# Altium schematic sheet SDRAM.SchDoc
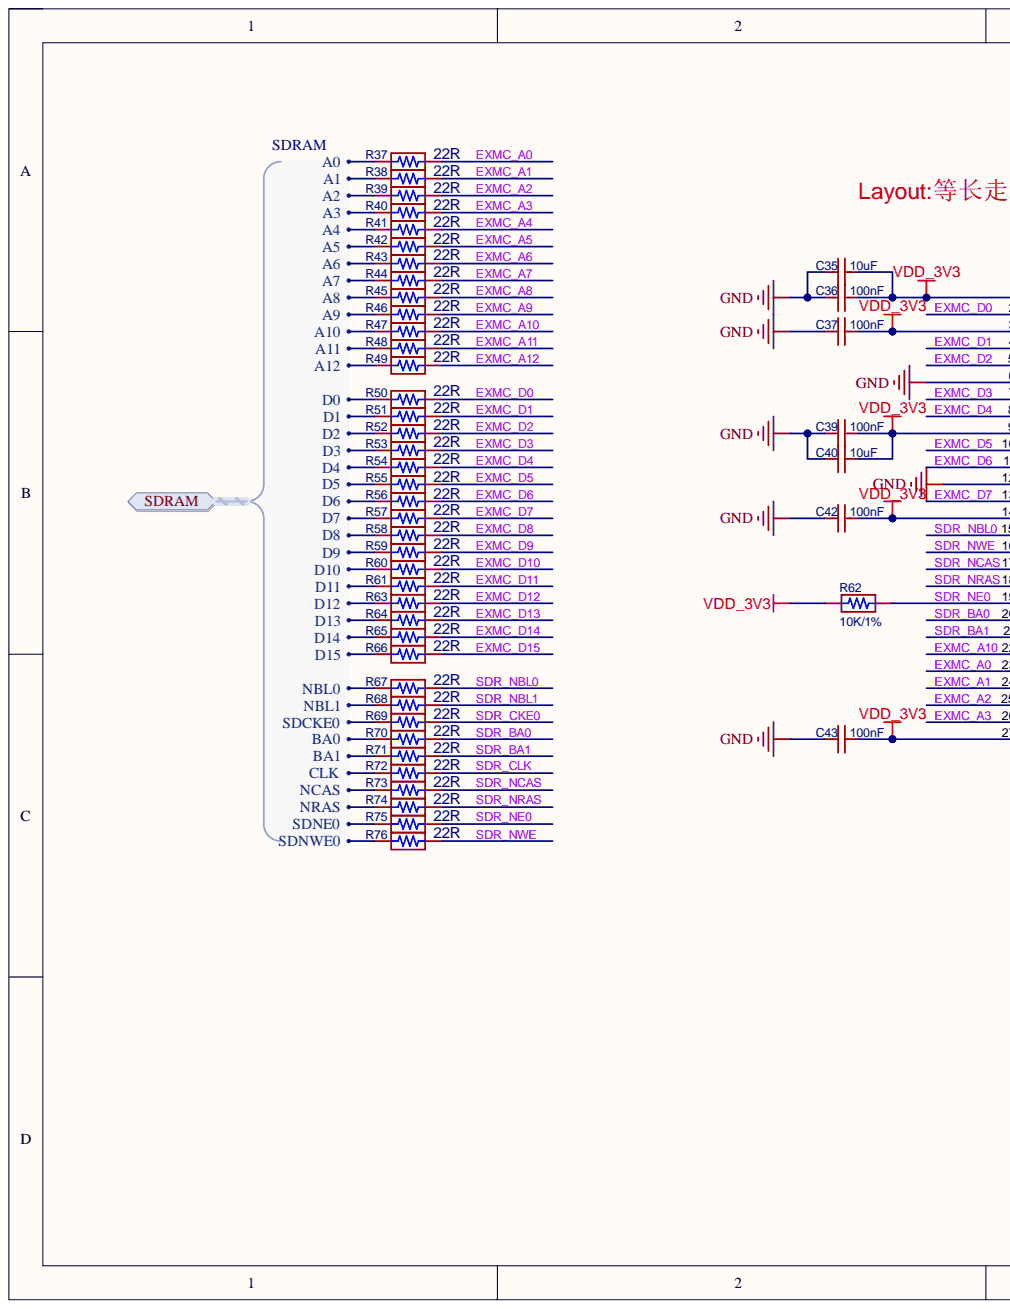
[diagram p0: SDRAM.SchDoc, left]
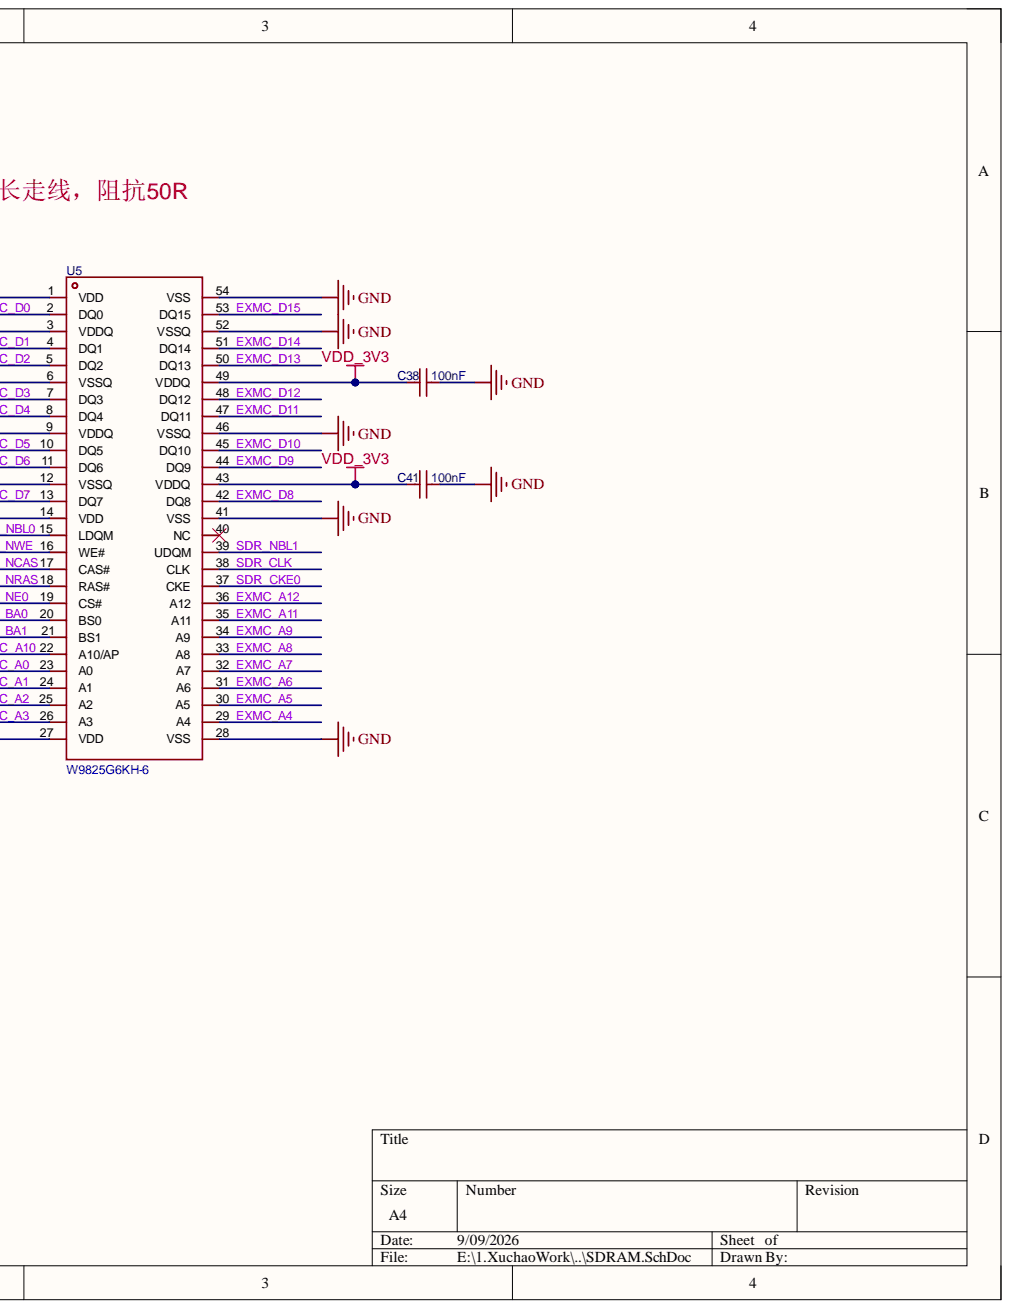
[diagram p1: SDRAM.SchDoc, right]

## Parts
C35  10uF  [C0402]
C36  100nF  [C0402]
C37  100nF  [C0402]
C38  100nF  [C0402]
C39  100nF  [C0402]
C40  10uF  [C0402]
C41  100nF  [C0402]
C42  100nF  [C0402]
C43  100nF  [C0402]
R37  22R  [R0402]
R38  22R  [R0402]
R39  22R  [R0402]
R40  22R  [R0402]
R41  22R  [R0402]
R42  22R  [R0402]
R43  22R  [R0402]
R44  22R  [R0402]
R45  22R  [R0402]
R46  22R  [R0402]
R47  22R  [R0402]
R48  22R  [R0402]
R49  22R  [R0402]
R50  22R  [R0402]
R51  22R  [R0402]
R52  22R  [R0402]
R53  22R  [R0402]
R54  22R  [R0402]
R55  22R  [R0402]
R56  22R  [R0402]
R57  22R  [R0402]
R58  22R  [R0402]
R59  22R  [R0402]
R60  22R  [R0402]
R61  22R  [R0402]
R62  10K/1%  [R0402]
R63  22R  [R0402]
R64  22R  [R0402]
R65  22R  [R0402]
R66  22R  [R0402]
R67  22R  [R0402]
R68  22R  [R0402]
R69  22R  [R0402]
R70  22R  [R0402]
R71  22R  [R0402]
R72  22R  [R0402]
R73  22R  [R0402]
R74  22R  [R0402]
R75  22R  [R0402]
R76  22R  [R0402]
U5  W9825G6KH-6  [TSOP-54_L22.2-W10.2-P0.80-LS11.8-BL]

## Ports
SDRAM, SDRAM.A0, SDRAM.A1, SDRAM.A2, SDRAM.A3, SDRAM.A4, SDRAM.A5, SDRAM.A6, SDRAM.A7, SDRAM.A8, SDRAM.A9, SDRAM.A10, SDRAM.A11, SDRAM.A12, SDRAM.BA0, SDRAM.BA1, SDRAM.CLK, SDRAM.D0, SDRAM.D1, SDRAM.D2, SDRAM.D3, SDRAM.D4, SDRAM.D5, SDRAM.D6, SDRAM.D7, SDRAM.D8, SDRAM.D9, SDRAM.D10, SDRAM.D11, SDRAM.D12, SDRAM.D13, SDRAM.D14, SDRAM.D15, SDRAM.NBL0, SDRAM.NBL1, SDRAM.NCAS, SDRAM.NRAS, SDRAM.SDCKE0, SDRAM.SDNE0, SDRAM.SDNWE0

## Nets
GND: C35.2 C36.1 C37.1 C38.2 C39.1 C40.2 C41.2 C42.1 C43.1 U5.6(VSSQ) U5.12(VSSQ) U5.28(VSS) U5.41(VSS) U5.46(VSSQ) U5.52(VSSQ) U5.54(VSS)
VDD_3V3: C35.1 C36.2 C37.2 C38.1 C39.2 C40.1 C41.1 C42.2 C43.2 R62.1 U5.1(VDD) U5.3(VDDQ) U5.9(VDDQ) U5.14(VDD) U5.27(VDD) U5.43(VDDQ) U5.49(VDDQ)
EXMC_A0: R37.2 U5.23(A0)
EXMC_A1: R38.2 U5.24(A1)
EXMC_A2: R39.2 U5.25(A2)
EXMC_A3: R40.2 U5.26(A3)
EXMC_A4: R41.2 U5.29(A4)
EXMC_A5: R42.2 U5.30(A5)
EXMC_A6: R43.2 U5.31(A6)
EXMC_A7: R44.2 U5.32(A7)
EXMC_A8: R45.2 U5.33(A8)
EXMC_A9: R46.2 U5.34(A9)
EXMC_A10: R47.2 U5.22(A10/AP)
EXMC_A11: R48.2 U5.35(A11)
EXMC_A12: R49.2 U5.36(A12)
EXMC_D0: R50.2 U5.2(DQ0)
EXMC_D1: R51.2 U5.4(DQ1)
EXMC_D2: R52.2 U5.5(DQ2)
EXMC_D3: R53.2 U5.7(DQ3)
EXMC_D4: R54.2 U5.8(DQ4)
EXMC_D5: R55.2 U5.10(DQ5)
EXMC_D6: R56.2 U5.11(DQ6)
EXMC_D7: R57.2 U5.13(DQ7)
EXMC_D8: R58.2 U5.42(DQ8)
EXMC_D9: R59.2 U5.44(DQ9)
EXMC_D10: R60.2 U5.45(DQ10)
EXMC_D11: R61.2 U5.47(DQ11)
EXMC_D12: R63.2 U5.48(DQ12)
EXMC_D13: R64.2 U5.50(DQ13)
EXMC_D14: R65.2 U5.51(DQ14)
EXMC_D15: R66.2 U5.53(DQ15)
SDR_BA0: R70.2 U5.20(BS0)
SDR_BA1: R71.2 U5.21(BS1)
SDR_CKE0: R69.2 U5.37(CKE)
SDR_CLK: R72.2 U5.38(CLK)
SDR_NBL0: R67.2 U5.15(LDQM)
SDR_NBL1: R68.2 U5.39(UDQM)
SDR_NCAS: R73.2 U5.17(CAS#)
SDR_NE0: R62.2 R75.2 U5.19(CS#)
SDR_NRAS: R74.2 U5.18(RAS#)
SDR_NWE: R76.2 U5.16(WE#)
NetR37_1: R37.1 ; port SDRAM
NetR38_1: R38.1 ; port SDRAM
NetR39_1: R39.1 ; port SDRAM
NetR40_1: R40.1 ; port SDRAM
NetR41_1: R41.1 ; port SDRAM
NetR42_1: R42.1 ; port SDRAM
NetR43_1: R43.1 ; port SDRAM
NetR44_1: R44.1 ; port SDRAM
NetR45_1: R45.1 ; port SDRAM
NetR46_1: R46.1 ; port SDRAM
NetR47_1: R47.1 ; port SDRAM
NetR48_1: R48.1 ; port SDRAM
NetR49_1: R49.1 ; port SDRAM
NetR50_1: R50.1 ; port SDRAM
NetR51_1: R51.1 ; port SDRAM
NetR52_1: R52.1 ; port SDRAM
NetR53_1: R53.1 ; port SDRAM
NetR54_1: R54.1 ; port SDRAM
NetR55_1: R55.1 ; port SDRAM
NetR56_1: R56.1 ; port SDRAM
NetR57_1: R57.1 ; port SDRAM
NetR58_1: R58.1 ; port SDRAM
NetR59_1: R59.1 ; port SDRAM
NetR60_1: R60.1 ; port SDRAM
NetR61_1: R61.1 ; port SDRAM
NetR63_1: R63.1 ; port SDRAM
NetR64_1: R64.1 ; port SDRAM
NetR65_1: R65.1 ; port SDRAM
NetR66_1: R66.1 ; port SDRAM
NetR67_1: R67.1 ; port SDRAM
NetR68_1: R68.1 ; port SDRAM
NetR69_1: R69.1 ; port SDRAM
NetR70_1: R70.1 ; port SDRAM
NetR71_1: R71.1 ; port SDRAM
NetR72_1: R72.1 ; port SDRAM
NetR73_1: R73.1 ; port SDRAM
NetR74_1: R74.1 ; port SDRAM
NetR75_1: R75.1 ; port SDRAM
NetR76_1: R76.1 ; port SDRAM
NetU5_40: U5.40(NC)

## Library data of the parts
C35-C43 = 0SMD_CAP: Symbol: CL10A106KP8NNNC; Component Kind: Standard; Library Name: CYKJ_LibPkg.IntLib
R37-R76 = 0SMD_RES: Component Kind: Standard; Library Name: CYKJ_LibPkg.IntLib
U5 = SDRAM_W9825G6KH-6: Component Kind: Standard; Symbol: W9825G6KH-6; Library Name: CYKJ_LibPkg.IntLib
